# Altium schematic sheet STM32H563RI.SchDoc
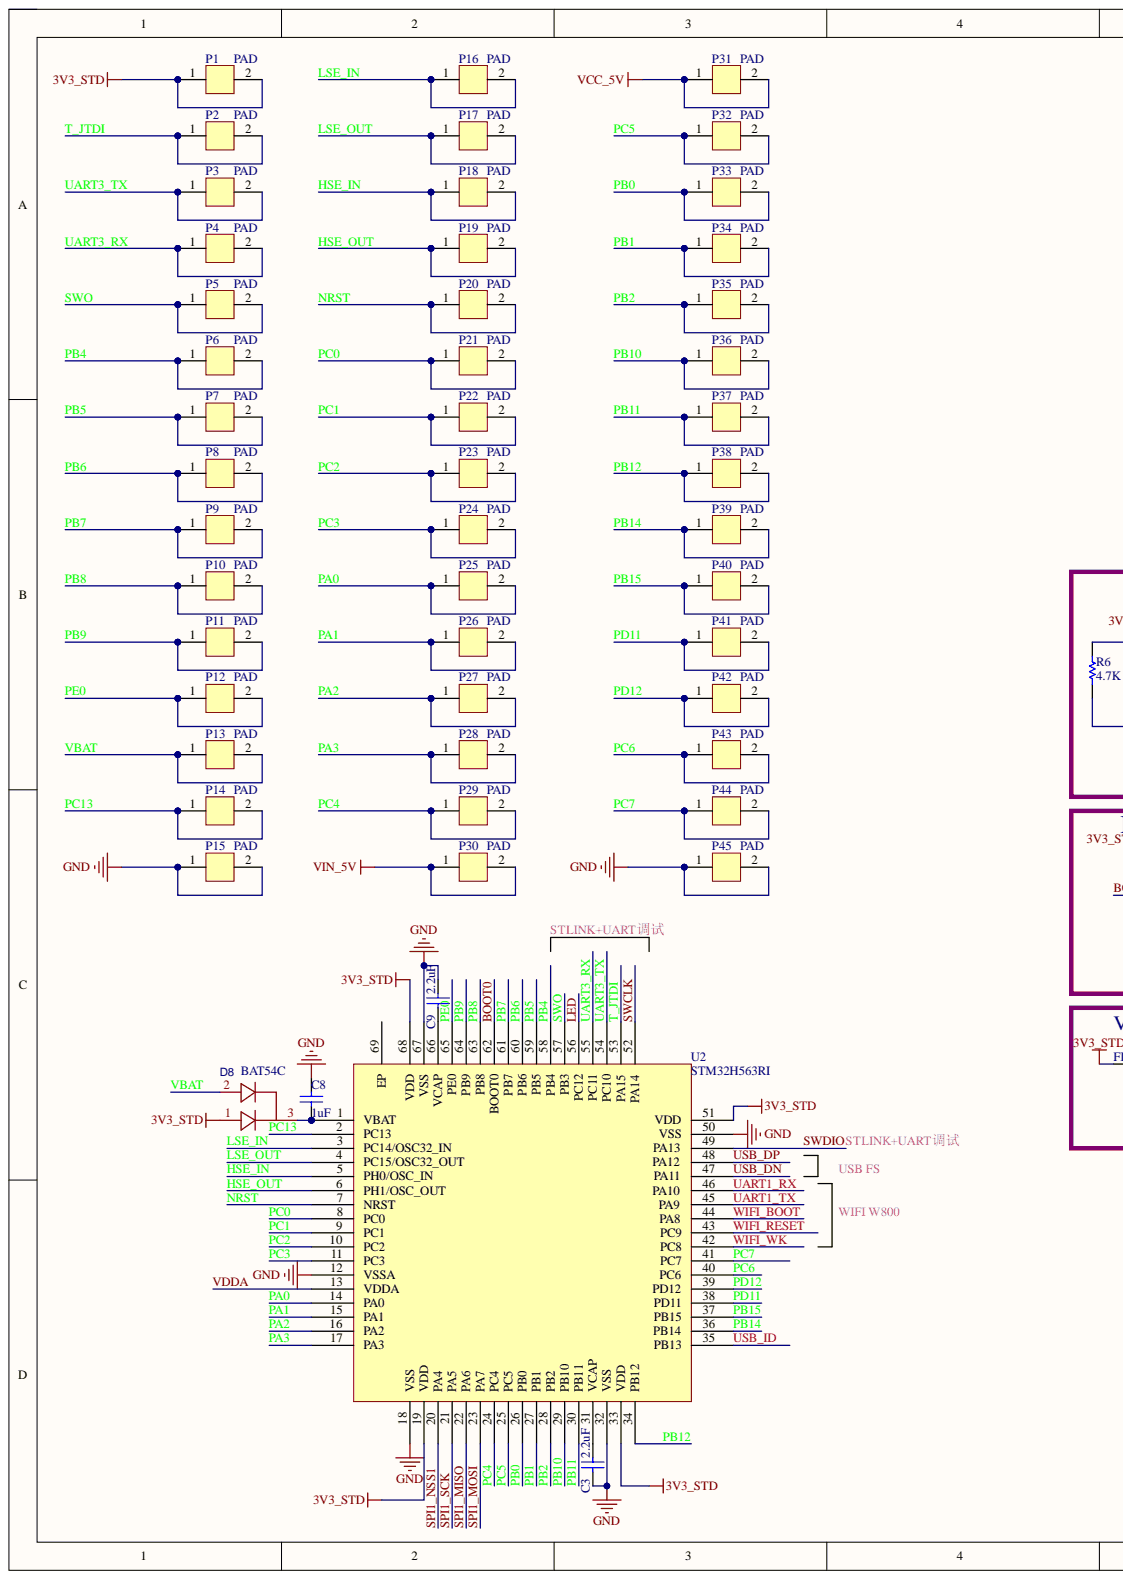
[diagram p0: STM32H563RI.SchDoc, left]
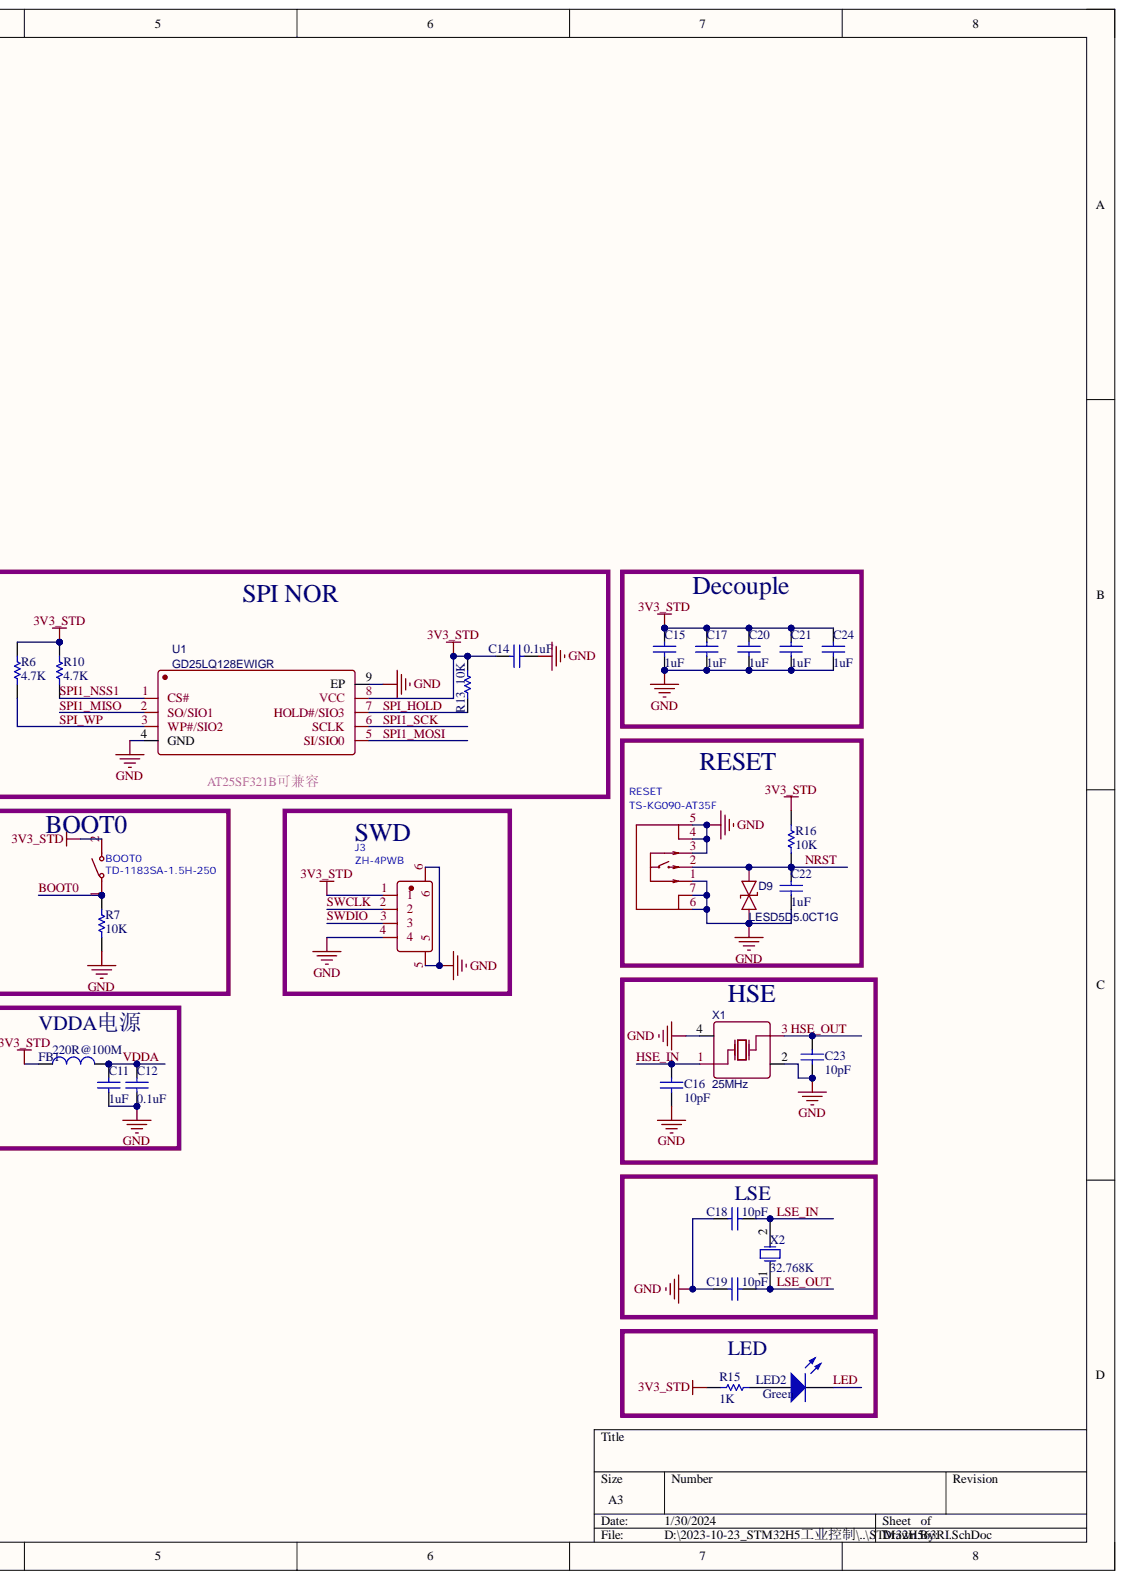
[diagram p1: STM32H563RI.SchDoc, right]

## Parts
BOOT0  TD-1183SA-1.5H-250  [KEY-SMD_L3.0-W2.5-LS4.0]
C3  2.2uF  [0402]
C8  1uF  [0201]
C9  2.2uF  [0402]
C11  1uF  [0201]
C12  0.1uF  [0201]
C14  0.1uF  [0201]
C15  1uF  [0201]
C16  10pF  [0201]
C17  1uF  [0201]
C18  10pF  [0201]
C19  10pF  [0201]
C20  1uF  [0201]
C21  1uF  [0201]
C22  1uF  [0201]
C23  10pF  [0201]
C24  1uF  [0201]
D8  BAT54C  [SOT23]
D9  LESD5D5.0CT1G  [SOD-523_L1.2-W0.8-LS1.6-BI]
FB1  220R@100M  [0402]
J3  ZH-4PWB  [CONN-SMD_4P-P1.50_DEALON_ZH-4PWB]
LED2  Green  [LED0402]
P1  PAD  [STAMP_PAD_0.8_1.3]
P2  PAD  [STAMP_PAD_0.8_1.3]
P3  PAD  [STAMP_PAD_0.8_1.3]
P4  PAD  [STAMP_PAD_0.8_1.3]
P5  PAD  [STAMP_PAD_0.8_1.3]
P6  PAD  [STAMP_PAD_0.8_1.3]
P7  PAD  [STAMP_PAD_0.8_1.3]
P8  PAD  [STAMP_PAD_0.8_1.3]
P9  PAD  [STAMP_PAD_0.8_1.3]
P10  PAD  [STAMP_PAD_0.8_1.3]
P11  PAD  [STAMP_PAD_0.8_1.3]
P12  PAD  [STAMP_PAD_0.8_1.3]
P13  PAD  [STAMP_PAD_0.8_1.3]
P14  PAD  [STAMP_PAD_0.8_1.3]
P15  PAD  [STAMP_PAD_0.8_1.3]
P16  PAD  [STAMP_PAD_0.8_1.3]
P17  PAD  [STAMP_PAD_0.8_1.3]
P18  PAD  [STAMP_PAD_0.8_1.3]
P19  PAD  [STAMP_PAD_0.8_1.3]
P20  PAD  [STAMP_PAD_0.8_1.3]
P21  PAD  [STAMP_PAD_0.8_1.3]
P22  PAD  [STAMP_PAD_0.8_1.3]
P23  PAD  [STAMP_PAD_0.8_1.3]
P24  PAD  [STAMP_PAD_0.8_1.3]
P25  PAD  [STAMP_PAD_0.8_1.3]
P26  PAD  [STAMP_PAD_0.8_1.3]
P27  PAD  [STAMP_PAD_0.8_1.3]
P28  PAD  [STAMP_PAD_0.8_1.3]
P29  PAD  [STAMP_PAD_0.8_1.3]
P30  PAD  [STAMP_PAD_0.8_1.3]
P31  PAD  [STAMP_PAD_0.8_1.3]
P32  PAD  [STAMP_PAD_0.8_1.3]
P33  PAD  [STAMP_PAD_0.8_1.3]
P34  PAD  [STAMP_PAD_0.8_1.3]
P35  PAD  [STAMP_PAD_0.8_1.3]
P36  PAD  [STAMP_PAD_0.8_1.3]
P37  PAD  [STAMP_PAD_0.8_1.3]
P38  PAD  [STAMP_PAD_0.8_1.3]
P39  PAD  [STAMP_PAD_0.8_1.3]
P40  PAD  [STAMP_PAD_0.8_1.3]
P41  PAD  [STAMP_PAD_0.8_1.3]
P42  PAD  [STAMP_PAD_0.8_1.3]
P43  PAD  [STAMP_PAD_0.8_1.3]
P44  PAD  [STAMP_PAD_0.8_1.3]
P45  PAD  [STAMP_PAD_0.8_1.3]
R6  4.7K  [0201]
R7  10K  [0201]
R10  4.7K  [0201]
R13  10K  [0201]
R15  1K  [0201]
R16  10K  [0201]
RESET  TS-KG090-AT35F  [SW-SMD_TS-KG090-AT35F]
U1  GD25LQ128EWIGR  [WSON-8_L5.0-W6.0-P1.27-BL-EP]
U2  STM32H563RI  [VFQFPN-68_L8.0-W8.0-P0.40-TL-EP6.4]
X1  25MHz  [CRYSTAL-SMD_4P-L2.5-W2.0-BL]
X2  value 32.768K  [SMD3215]  (hidden comment: XTAL)

## Nets
3V3_STD: BOOT0.2 C14.2 C15.2 C17.2 C20.2 C21.2 C24.2 D8.1 FB1.1 J3.1 P1.1 P1.2 R6.1 R10.1 R13.1 R15.2 R16.1 U1.8(VCC) U2.19(VDD) U2.33(VDD) U2.51(VDD) U2.68(VDD)
GND: C3.2 C8.2 C9.1 C11.2 C12.2 C14.1 C15.1 C16.2 C17.1 C18.1 C19.1 C20.1 C21.1 C22.2 C23.2 C24.1 D9.1 J3.4 J3.5 J3.6 P15.1 P15.2 P45.1 P45.2 R7.2 RESET.1 RESET.3 RESET.4 RESET.5 RESET.6 RESET.7 U1.4(GND) U1.9(EP) U2.12(VSSA) U2.18(VSS) U2.32(VSS) U2.50(VSS) U2.67(VSS) X1.2 X1.4
VCC_5V: P31.1 P31.2
VIN_5V: P30.1 P30.2
BOOT0: BOOT0.1 R7.1 U2.62(BOOT0)
HSE_IN: C16.1 P18.1 P18.2 U2.5(PH0/OSC_IN) X1.1
HSE_OUT: C23.1 P19.1 P19.2 U2.6(PH1/OSC_OUT) X1.3
LED: LED2.2 U2.56(PC12)
LSE_IN: C18.2 P16.1 P16.2 U2.3(PC14/OSC32_IN) X2.2
LSE_OUT: C19.2 P17.1 P17.2 U2.4(PC15/OSC32_OUT) X2.1
NRST: C22.1 D9.2 P20.1 P20.2 R16.2 RESET.2 U2.7(NRST)
PA0: P25.1 P25.2 U2.14(PA0)
PA1: P26.1 P26.2 U2.15(PA1)
PA2: P27.1 P27.2 U2.16(PA2)
PA3: P28.1 P28.2 U2.17(PA3)
PB0: P33.1 P33.2 U2.26(PB0)
PB1: P34.1 P34.2 U2.27(PB1)
PB2: P35.1 P35.2 U2.28(PB2)
PB4: P6.1 P6.2 U2.58(PB4)
PB5: P7.1 P7.2 U2.59(PB5)
PB6: P8.1 P8.2 U2.60(PB6)
PB7: P9.1 P9.2 U2.61(PB7)
PB8: P10.1 P10.2 U2.63(PB8)
PB9: P11.1 P11.2 U2.64(PB9)
PB10: P36.1 P36.2 U2.29(PB10)
PB11: P37.1 P37.2 U2.30(PB11)
PB12: P38.1 P38.2 U2.34(PB12)
PB14: P39.1 P39.2 U2.36(PB14)
PB15: P40.1 P40.2 U2.37(PB15)
PC0: P21.1 P21.2 U2.8(PC0)
PC1: P22.1 P22.2 U2.9(PC1)
PC2: P23.1 P23.2 U2.10(PC2)
PC3: P24.1 P24.2 U2.11(PC3)
PC4: P29.1 P29.2 U2.24(PC4)
PC5: P32.1 P32.2 U2.25(PC5)
PC6: P43.1 P43.2 U2.40(PC6)
PC7: P44.1 P44.2 U2.41(PC7)
PC13: P14.1 P14.2 U2.2(PC13)
PD11: P41.1 P41.2 U2.38(PD11)
PD12: P42.1 P42.2 U2.39(PD12)
PE0: P12.1 P12.2 U2.65(PE0)
SPI1_MISO: U1.2(SO/SIO1) U2.22(PA6)
SPI1_MOSI: U1.5(SI/SIO0) U2.23(PA7)
SPI1_NSS1: R10.2 U1.1(CS#) U2.20(PA4)
SPI1_SCK: U1.6(SCLK) U2.21(PA5)
SPI_HOLD: R13.2 U1.7(HOLD#/SIO3)
SPI_WP: R6.2 U1.3(WP#/SIO2)
SWCLK: J3.2 U2.52(PA14)
SWDIO: J3.3 U2.49(PA13)
SWO: P5.1 P5.2 U2.57(PB3)
T_JTDI: P2.1 P2.2 U2.53(PA15)
UART1_RX: U2.46(PA10)
UART1_TX: U2.45(PA9)
UART3_RX: P4.1 P4.2 U2.55(PC11)
UART3_TX: P3.1 P3.2 U2.54(PC10)
USB_DN: U2.47(PA11)
USB_DP: U2.48(PA12)
USB_ID: U2.35(PB13)
VBAT: D8.2 P13.1 P13.2
VDDA: C11.1 C12.1 FB1.2 U2.13(VDDA)
WIFI_BOOT: U2.44(PA8)
WIFI_RESET: U2.43(PC9)
WIFI_WK: U2.42(PC8)
NetC3_1: C3.1 U2.31(VCAP)
NetC8_1: C8.1 D8.3 U2.1(VBAT)
NetC9_2: C9.2 U2.66(VCAP)
NetLED2_1: LED2.1 R15.1

Not connected: U2 69(EP)

## Library data of the parts
C3 C9 = Cap: Component Kind: Standard; Value: 2.2uF; Library Name: STLINK.SCHLIB
C8 C11 C12 C14 C15 C17 C20-C22 C24 = Cap: Capacitor; PartNumber: CAP100NF; Component Kind: Standard; LatestRevisionDate: 17-Jul-2002; LatestRevisionNote: Re-released for DXP Platform.; Library Name: Miscellaneous Devices.IntLib; PackageReference: 0402; Published: 8-Jun-2000; Signal Integrity: Cap; Simulation: CAP; Publisher: Altium Limited
  C8 C11 C15 C17 C20-C22 C24: Value: 1uF
  C12 C14: Value: 0.1uF
C16 C18 C19 C23 = Cap: Capacitor; PartNumber: CAP18PF; Component Kind: Standard; LatestRevisionDate: 17-Jul-2002; LatestRevisionNote: Re-released for DXP Platform.; Library Name: Miscellaneous Devices.IntLib; PackageReference: 0402; Published: 8-Jun-2000; Signal Integrity: Cap; Simulation: CAP; Value: 10pF; Publisher: Altium Limited
D8 = BAT54C: BOM_Manufacturer: Jingdao; BOM_Manufacturer Part: 1N4148W; BOM_Supplier: LCSC; BOM_Supplier Part: C115103; Component Kind: Standard; Library Name: HM2.SchLib; spicePre: D; spiceSymbolName: 1N4148W_C115103; Value: BAT54C
D9 = LESD5D5.0CT1G: BOM_Manufacturer: Leshan Radio; Contributor: LCSC; BOM_JLCPCB Part Class: Extended Part; BOM_Manufacturer Part: LESD5D5.0CT1G; BOM_Supplier: LCSC; BOM_Supplier Part: C383211; Component Kind: Standard; spicePre: D; spiceSymbolName: LESD5D5.0CT1G
FB1 = Inductor: VALUE: 600R,1A; Component Kind: Standard; JLC NO: C133527; Library Name: MyDevice.SchLib
LED2 = LED2: Typical RED, GREEN, YELLOW, AMBER GaAs LED; Component Kind: Standard; LatestRevisionDate: 17-Jul-2002; LatestRevisionNote: Re-released for DXP Platform.; Library Name: Miscellaneous Devices.IntLib; PackageDescription: SM LED; 2 Flat Leads; PackageReference: 3.2X1.6X1.1; PackageVersion: Feb-2002; Published: 8-Jun-2000; Simulation: LED2; Value: Green; Publisher: Altium Limited
P1-P45 = STAMP_PAD: Component Kind: Standard; Library Name: HM2.SchLib
R6 R7 R10 R13 R15 R16 = Res1: Resistor; PartNumber: RES2.2K; Component Kind: Standard; LatestRevisionDate: 17-Jul-2002; LatestRevisionNote: Re-released for DXP Platform.; Library Name: Miscellaneous Devices.IntLib; PackageReference: 0402; Published: 8-Jun-2000; Signal Integrity: Res; Simulation: RESISTOR; Publisher: Altium Limited
  R6 R10: Value: 4.7K
  R7 R13 R16: Value: 10K
  R15: Value: 1K
U1 = MX35LF1GE4AB-Z4I_C402160: Contributor: LCSC; BOM_JLCPCB Part Class: Extended Part; BOM_Supplier: LCSC; BOM_Supplier Part: C2928889; Component Kind: Standard; spicePre: U
U2 = STM32H563RI: STM32F103RETX,64PIN; Component Kind: Standard; Library Name: HM2.SchLib; Value: STM32H563RI
X1 = 24MHz_1: BOM_Manufacturer: JGHC; BOM_Manufacturer Part: X252024MPB4SC; Contributor: LCSC; nameAlias: Frequency; BOM_Supplier: LCSC; BOM_Supplier Part: C65961; Component Kind: Standard; Library Name: HM2.SchLib; spicePre: X; spiceSymbolName: X252024MPB4SC
X2 = XTAL: Crystal Oscillator; Component Kind: Standard; LatestRevisionDate: 17-Jul-2002; LatestRevisionNote: Re-released for DXP Platform.; Library Name: Miscellaneous Devices.IntLib; PackageDescription: Crystal, Thru-Hole; 2 Leads; Body 3.1 x 8.2 mm (Dia.xH); PackageReference: R38; Published: 8-Jun-2000; Publisher: Altium Limited
BOOT0 = TD-1183SA-1.5H-250: Component Kind: Standard; JLC NO: C2905189
J3 = ZH-4PWB: Component Kind: Standard; JLC NO: C2905016
RESET = TS-KG090-AT35F: Component Kind: Standard; JLC: C2874601
